# Altium schematic sheet DigitalLabBoard_Switches.SchDoc(DigitalLabBoard_Switches)
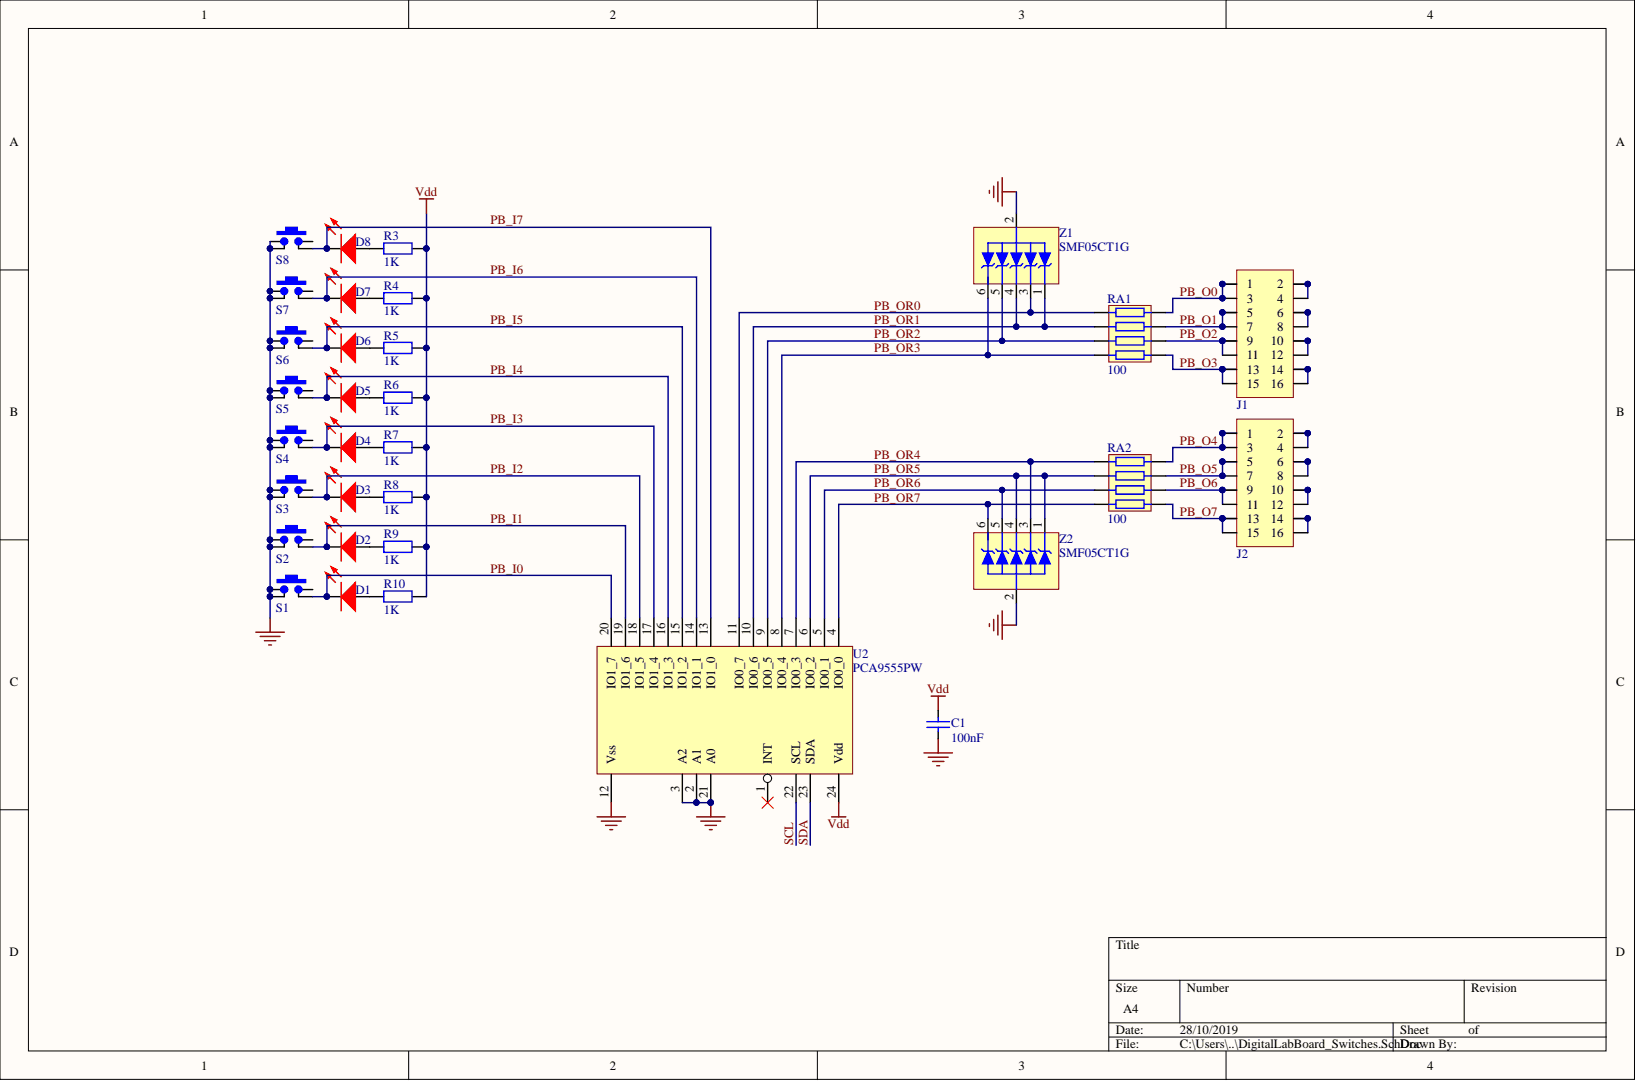
[diagram p0: DigitalLabBoard_Switches.SchDoc]

## Parts
C1  100nF  [CCNP2012-0805]
D1  LED Red  [LED1608-0603-RED]  (value not drawn)
D2  LED Red  [LED1608-0603-RED]  (value not drawn)
D3  LED Red  [LED1608-0603-RED]  (value not drawn)
D4  LED Red  [LED1608-0603-RED]  (value not drawn)
D5  LED Red  [LED1608-0603-RED]  (value not drawn)
D6  LED Red  [LED1608-0603-RED]  (value not drawn)
D7  LED Red  [LED1608-0603-RED]  (value not drawn)
D8  LED Red  [LED1608-0603-RED]  (value not drawn)
J1  2x5 Header  [HDR2X8S]  (value not drawn)
J2  2x5 Header  [HDR2X8S]  (value not drawn)
R3  1K  [CR1608-0603]
R4  1K  [CR1608-0603]
R5  1K  [CR1608-0603]
R6  1K  [CR1608-0603]
R7  1K  [CR1608-0603]
R8  1K  [CR1608-0603]
R9  1K  [CR1608-0603]
R10  1K  [CR1608-0603]
RA1  100  [CR1206-0603_X4]
RA2  100  [CR1206-0603_X4]
S1  SW_PB  [SW-SMD-4_5.1x5.1x2]  (value not drawn)
S2  SW_PB  [SW-SMD-4_5.1x5.1x2]  (value not drawn)
S3  SW_PB  [SW-SMD-4_5.1x5.1x2]  (value not drawn)
S4  SW_PB  [SW-SMD-4_5.1x5.1x2]  (value not drawn)
S5  SW_PB  [SW-SMD-4_5.1x5.1x2]  (value not drawn)
S6  SW_PB  [SW-SMD-4_5.1x5.1x2]  (value not drawn)
S7  SW_PB  [SW-SMD-4_5.1x5.1x2]  (value not drawn)
S8  SW_PB  [SW-SMD-4_5.1x5.1x2]  (value not drawn)
U2  PCA9555PW  [SOP65P780-24L]
Z1  SMF05CT1G  [SC70_N]
Z2  SMF05CT1G  [SC70_N]

## Nets
GND: C1.1 S1.1 S1.1b S2.1 S2.1b S3.1 S3.1b S4.1 S4.1b S5.1 S5.1b S6.1 S6.1b S7.1 S7.1b S8.1 S8.1b U2.2(A1) U2.3(A2) U2.12(Vss) U2.21(A0) Z1.2 Z2.2
Vdd: C1.2 R3.2 R4.2 R5.2 R6.2 R7.2 R8.2 R9.2 R10.2 U2.24(Vdd)
PB_I0: D1.K S1.2 U2.20(IO1_7)
PB_I1: D2.K S2.2 U2.19(IO1_6)
PB_I2: D3.K S3.2 U2.18(IO1_5)
PB_I3: D4.K S4.2 U2.17(IO1_4)
PB_I4: D5.K S5.2 U2.16(IO1_3)
PB_I5: D6.K S6.2 U2.15(IO1_2)
PB_I6: D7.K S7.2 U2.14(IO1_1)
PB_I7: D8.K S8.2 U2.13(IO1_0)
PB_O0: J1.1 J1.2 J1.3 J1.4 RA1.8
PB_O1: J1.5 J1.6 J1.7 J1.8 RA1.7
PB_O2: J1.9 J1.10 J1.11 J1.12 RA1.6
PB_O3: J1.13 J1.14 J1.15 J1.16 RA1.5
PB_O4: J2.1 J2.2 J2.3 J2.4 RA2.8
PB_O5: J2.5 J2.6 J2.7 J2.8 RA2.7
PB_O6: J2.9 J2.10 J2.11 J2.12 RA2.6
PB_O7: J2.13 J2.14 J2.15 J2.16 RA2.5
PB_OR0: RA1.1 U2.11(IO0_7) Z1.3
PB_OR1: RA1.2 U2.10(IO0_6) Z1.1 Z1.4
PB_OR2: RA1.3 U2.9(IO0_5) Z1.5
PB_OR3: RA1.4 U2.8(IO0_4) Z1.6
PB_OR4: RA2.1 U2.7(IO0_3) Z2.3
PB_OR5: RA2.2 U2.6(IO0_2) Z2.1 Z2.4
PB_OR6: RA2.3 U2.5(IO0_1) Z2.5
PB_OR7: RA2.4 U2.4(IO0_0) Z2.6
SCL: U2.22(SCL)
SDA: U2.23(SDA)
NetD1_A: D1.A R10.1
NetD2_A: D2.A R9.1
NetD3_A: D3.A R8.1
NetD4_A: D4.A R7.1
NetD5_A: D5.A R6.1
NetD6_A: D6.A R5.1
NetD7_A: D7.A R4.1
NetD8_A: D8.A R3.1

Not connected: S1 2b; S2 2b; S3 2b; S4 2b; S5 2b; S6 2b; S7 2b; S8 2b; U2 1(INT)

## Library data of the parts
C1 = Cap_CCNP_0805: Capacitor non-polar SMT 0805; Manufacturer: Generic Components; Class: Passive; Value: 100nF; SubClass: Capacitor; Simulation: CAP; Signal Integrity: Cap; Published: 08/06/2000; Library Name: MyLibrary.IntLib; Component Kind: Standard; Publisher: Altium Hobart Technology Centre
D1-D8 = LED-0603-Red: LED 0603 Red; Manufacturer: Generic Components; Class I: Optoelectronic; Revision: July-2002: Re-released for DXP Platform.; Published: 8-Jun-2000; Library Name: MySchLib.schlib; Farnell PN: 1581239; Component Kind: Standard; Class II: LED; Publisher: Altium Limited
J1 J2 = Header 2x8a: Header 2x8; Library Name: MyLibrary.IntLib; Component Kind: Standard
R3-R10 = Res_CR0603: Resistor SMT 0603; Manufacturer: Generic Components; Class: Passive; Value: 1K; SubClass: Resistor; Simulation: RESISTOR; Signal Integrity: Res; Published: 08/06/2000; Library Name: MyLibrary.IntLib; Component Kind: Standard; Publisher: Altium Hobart Technology Centre
RA1 RA2 = Res_CR0603x4: SMT x4 Resistor Array; Value: 100; Library Name: MyLibrary.IntLib; Component Kind: Standard
S1-S8 = SW_PB-ALPS-SKQGAFE010: Tactile Switch, Top Actuated, Surface Mount, Round Button; Manufacturer: Multicomp; Revision: 1.0; Library Name: MySchLib.schlib; Farnell PN: 9471880; Component Kind: Standard
U2 = PCA9555PW: 16-bit I2C I/O Expander (TSSOP24); Library Name: MySchLib.schlib; Component Kind: Standard
Z1 Z2 = SMF05CT1G: TVS DIODE ARRAY, 100W, 5V, SC-70; Library Name: MySchLib.schlib; Farnell PN: 2533147; Component Kind: Standard
